# Altium schematic sheet Digital_Input.SchDoc
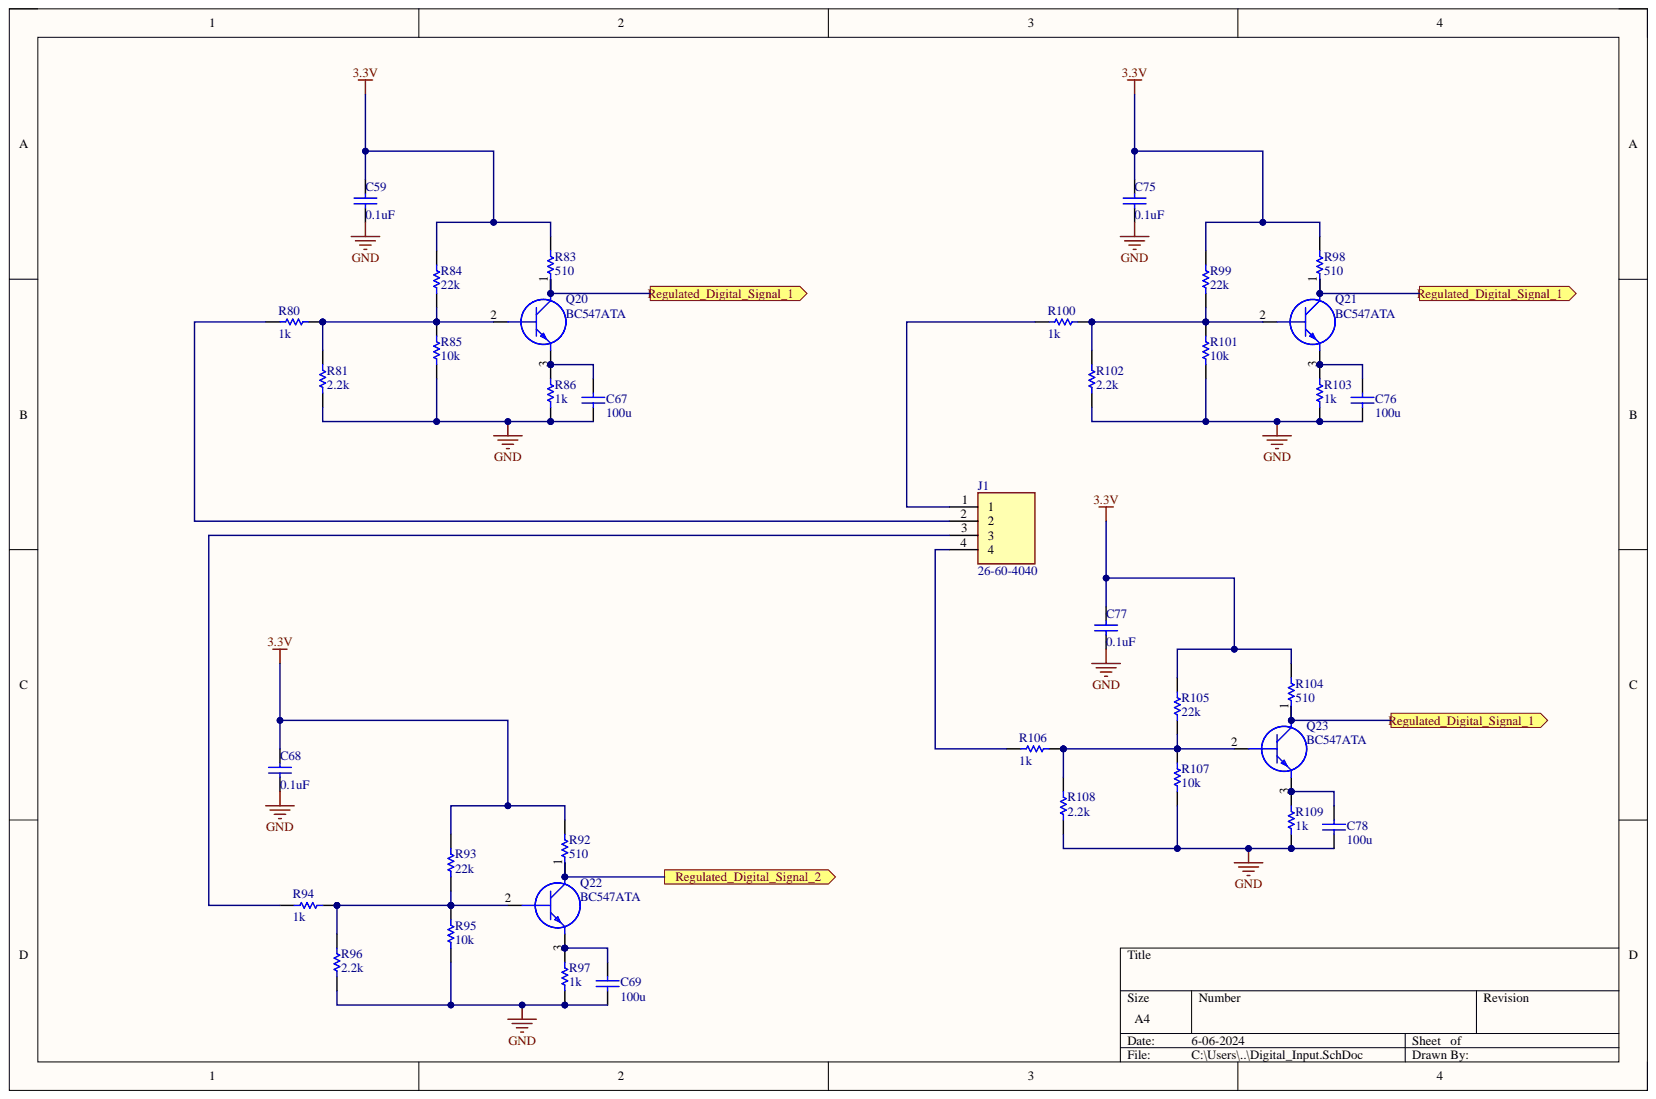
[diagram p0: Digital_Input.SchDoc]

## Parts
C59  value 0.1uF  [RAD-0.3]  (hidden comment: Cap)
C67  value 100u  (hidden comment: Capacitor)
C68  value 0.1uF  [RAD-0.3]  (hidden comment: Cap)
C69  value 100u  (hidden comment: Capacitor)
C75  value 0.1uF  [RAD-0.3]  (hidden comment: Cap)
C76  value 100u  (hidden comment: Capacitor)
C77  value 0.1uF  [RAD-0.3]  (hidden comment: Cap)
C78  value 100u  (hidden comment: Capacitor)
J1  26-60-4040  [SHDR4W155P0X396_1X4_1570X1001X1536P]
Q20  BC547ATA  [TO-92_(TO-226)]
Q21  BC547ATA  [TO-92_(TO-226)]
Q22  BC547ATA  [TO-92_(TO-226)]
Q23  BC547ATA  [TO-92_(TO-226)]
R80  value 1k  (hidden comment: Resistor)
R81  value 2.2k  (hidden comment: Resistor)
R83  value 510  (hidden comment: Resistor)
R84  value 22k  (hidden comment: Resistor)
R85  value 10k  (hidden comment: Resistor)
R86  value 1k  (hidden comment: Resistor)
R92  value 510  (hidden comment: Resistor)
R93  value 22k  (hidden comment: Resistor)
R94  value 1k  (hidden comment: Resistor)
R95  value 10k  (hidden comment: Resistor)
R96  value 2.2k  (hidden comment: Resistor)
R97  value 1k  (hidden comment: Resistor)
R98  value 510  (hidden comment: Resistor)
R99  value 22k  (hidden comment: Resistor)
R100  value 1k  (hidden comment: Resistor)
R101  value 10k  (hidden comment: Resistor)
R102  value 2.2k  (hidden comment: Resistor)
R103  value 1k  (hidden comment: Resistor)
R104  value 510  (hidden comment: Resistor)
R105  value 22k  (hidden comment: Resistor)
R106  value 1k  (hidden comment: Resistor)
R107  value 10k  (hidden comment: Resistor)
R108  value 2.2k  (hidden comment: Resistor)
R109  value 1k  (hidden comment: Resistor)

## Ports
Regulated_Digital_Signal_1, Regulated_Digital_Signal_2

## Nets
3.3V: C59.2 C68.2 C75.2 C77.2 R83.2 R84.2 R92.2 R93.2 R98.2 R99.2 R104.2 R105.2
GND: C59.1 C67.1 C68.1 C69.1 C75.1 C76.1 C77.1 C78.1 R81.1 R85.1 R86.1 R95.1 R96.1 R97.1 R101.1 R102.1 R103.1 R107.1 R108.1 R109.1
Regulated_Digital_Signal_1: Q20.1 Q21.1 Q23.1 R83.1 R98.1 R104.1 ; port Regulated_Digital_Signal_1
Regulated_Digital_Signal_2: Q22.1 R92.1 ; port Regulated_Digital_Signal_2
NetC67_2: C67.2 Q20.3 R86.2
NetC69_2: C69.2 Q22.3 R97.2
NetC76_2: C76.2 Q21.3 R103.2
NetC78_2: C78.2 Q23.3 R109.2
NetJ1_1: J1.1 R100.2
NetJ1_2: J1.2 R80.2
NetJ1_3: J1.3 R94.2
NetJ1_4: J1.4 R106.2
NetQ20_2: Q20.2 R80.1 R81.2 R84.1 R85.2
NetQ21_2: Q21.2 R99.1 R100.1 R101.2 R102.2
NetQ22_2: Q22.2 R93.1 R94.1 R95.2 R96.2
NetQ23_2: Q23.2 R105.1 R106.1 R107.2 R108.2

## Library data of the parts
C59 C68 C75 C77 = Cap: Capacitor; Component Kind: Standard; LatestRevisionDate: 17-Jul-2002; LatestRevisionNote: Re-released for DXP Platform.; Library Name: Miscellaneous Devices.IntLib; PackageDescription: Radial Cap, Thru-Hole; 2 Leads; 0.3 in Pin Spacing; PackageReference: RAD-0.3; Published: 8-Jun-2000; Signal Integrity: Cap; Simulation: CAP; Publisher: Altium Limited
C67 C69 C76 C78 = Capacitor: SimulationGenericComponent: True; Component Kind: Standard; Description: Capacitor; Library Name: Simulation Generic Components.IntLib; Simulation: C_Capacitor_Default
J1 = 26-60-4040: Connector; Manufacturer_Name: Molex; Component Kind: Standard; Datasheet Link: http://www.molex.com/pdm_docs/sd/026604040_sd.pdf; Height: 15.36mm; Manufacturer_Part_Number: 26-60-4040; Mouser Part Number: 538-26-60-4040; Mouser Price/Stock: https://www.mouser.co.uk/ProductDetail/Molex/26-60-4040?qs=tRPrwvvr%2FuiQpDQcO3P3Lw%3D%3D
Q20-Q23 = BC547ATA: Transistor BJT NPN; Manufacturer_Name: onsemi; Arrow Part Number: BC547ATA; Arrow Price/Stock: https://www.arrow.com/en/products/bc547ata/on-semiconductor?region=europe; Component Kind: Standard; Datasheet Link: https://www.onsemi.com/pub/Collateral/BC550-D.pdf; Manufacturer_Part_Number: BC547ATA; Mouser Part Number: 512-BC547ATA; Mouser Price/Stock: https://www.mouser.co.uk/ProductDetail/onsemi-Fairchild/BC547ATA?qs=ljbEvF4DwOMRdLfuzO8N4w%3D%3D; Simulation: NPN_Mod_Gummel_Poon
R80 R81 R83-R86 R92-R109 = Resistor: SimulationGenericComponent: True; TC1: 0; Component Kind: Standard; Description: Resistor; Library Name: Simulation Generic Components.IntLib; Simulation: R_Resistor_Default; TC2: 0
